# Altium schematic sheet DigitalLabBoard_Processor.SchDoc(DigitalLabBoard_Processor)
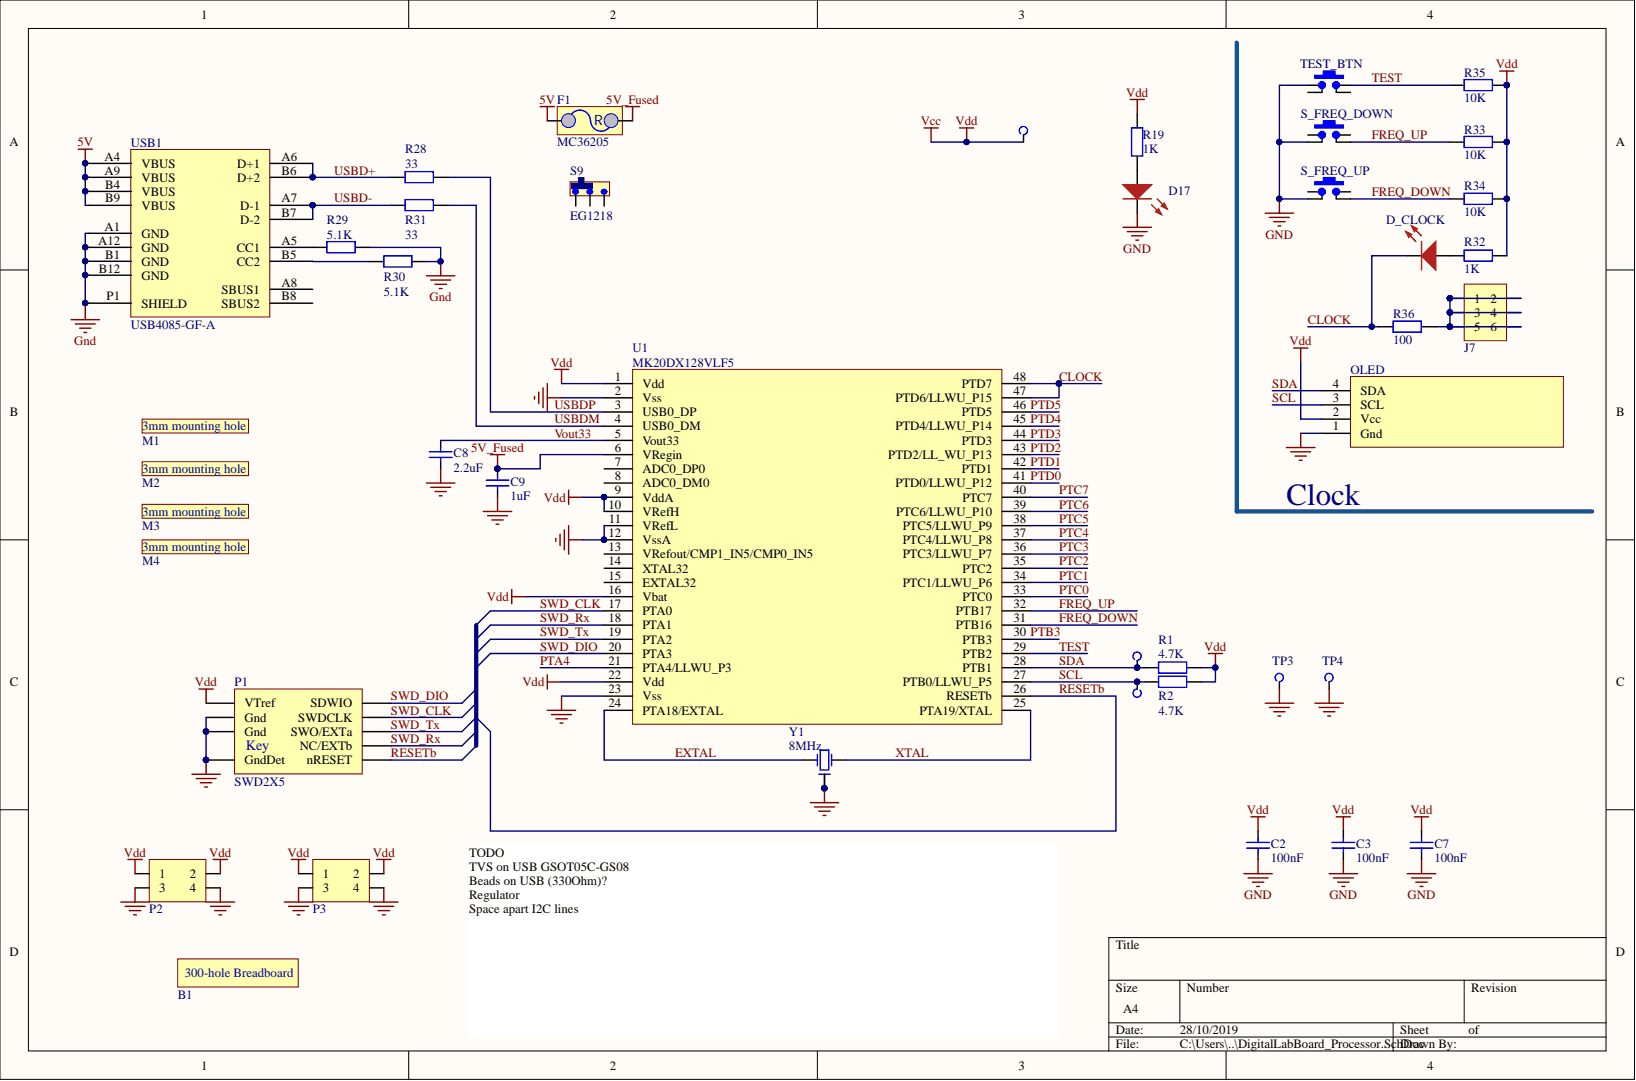
[diagram p0: DigitalLabBoard_Processor.SchDoc]

## Parts
B1  Breadboard - 300 Hole  [Breadboard - 300 holes]  (value not drawn)
C2  100nF  [CCNP1608-0603]
C3  100nF  [CCNP1608-0603]
C7  100nF  [CCNP1608-0603]
C8  2.2uF  [CCNP1608-0603]
C9  1uF  [CCNP1608-0603]
D17  LED Red  [LED1608-0603-RED]  (value not drawn)
D_CLOCK  LED Red  [LED1608-0603-RED]  (value not drawn)
F1  MC36205  [CR3225-1210]
J7  2x5 Header  [HDR2X3S]  (value not drawn)
M1  3mm mountng hole  [3MM_Hole]  (value not drawn)  (no BOM)
M2  3mm mountng hole  [3MM_Hole]  (value not drawn)  (no BOM)
M3  3mm mountng hole  [3MM_Hole]  (value not drawn)  (no BOM)
M4  3mm mountng hole  [3MM_Hole]  (value not drawn)  (no BOM)
OLED  OLED_Display  [OLED_Display]  (value not drawn)
P1  SWD2X5  [SWD-2x5]
P2  Header 2x2  [HDR_FEM2X2S]  (value not drawn)
P3  Header 2x2  [HDR_FEM2X2S]  (value not drawn)
R1  4.7K  [CR1608-0603]
R2  4.7K  [CR1608-0603]
R19  1K  [CR1608-0603]
R28  33  [CR1608-0603]
R29  5.1K  [CR1608-0603]
R30  5.1K  [CR1608-0603]
R31  33  [CR1608-0603]
R32  1K  [CR1608-0603]
R33  10K  [CR1608-0603]
R34  10K  [CR1608-0603]
R35  10K  [CR1608-0603]
R36  100  [CR1608-0603]
S9  EG1218  [Slide Switch -  EG1218]
S_FREQ_DOWN  SW_PB  [SW-SMD-4_5.1x5.1x2]  (value not drawn)
S_FREQ_UP  SW_PB  [SW-SMD-4_5.1x5.1x2]  (value not drawn)
TEST_BTN  SW_PB  [SW-SMD-4_5.1x5.1x2]  (value not drawn)
TP3  Gnd  [PCBPIN - 0.9mm]  (value not drawn)  (no BOM)
TP4  Gnd  [PCBPIN - 0.9mm]  (value not drawn)  (no BOM)
TP_SCL  SCL  [PCBPIN - 0.9mm]  (value not drawn)  (no BOM)
TP_SDA  SDA  [PCBPIN - 0.9mm]  (value not drawn)  (no BOM)
TP_Vdd  Vdd  [PCBPIN - 0.9mm]  (no BOM)
U1  MK20DX128VLF5  [TSQFP50P900X900-48M]
USB1  USB4085-GF-A  [USB4085-GF-A]
Y1  8MHz  [Crystal_SMT - EPSON-FA-238]

## Nets
5V: F1.1 USB1.A4(VBUS) USB1.A9(VBUS) USB1.B4(VBUS) USB1.B9(VBUS)
5V_Fused: C9.2 F1.2 U1.6(VRegin)
Gnd: C2.1 C3.1 C7.1 C8.1 C9.1 D17.K OLED.1(Gnd) P1.3(Gnd) P1.5(Gnd) P1.9(GndDet) P2.3 P2.4 P3.3 P3.4 R29.2 R30.2 S_FREQ_DOWN.2 S_FREQ_UP.2 TEST_BTN.2b TP3.1 TP4.1 U1.2(Vss) U1.11(VRefL) U1.12(VssA) U1.23(Vss) USB1.A1(GND) USB1.A12(GND) USB1.B1(GND) USB1.B12(GND) USB1.P1(SHIELD) Y1.2 Y1.4
Vcc: C2.2 C3.2 C7.2 OLED.2(Vcc) P1.1(VTref) P2.1 P2.2 P3.1 P3.2 R1.2 R2.2 R19.2 R32.2 R33.2 R34.2 R35.2 TP_Vdd.1 U1.1(Vdd) U1.9(VddA) U1.10(VRefH) U1.16(Vbat) U1.22(Vdd)
CLOCK: D_CLOCK.K R36.2 U1.47(PTD6/LLWU_P15) U1.48(PTD7)
EXTAL: U1.24(PTA18/EXTAL) Y1.3
FREQ_DOWN: R34.1 S_FREQ_UP.1 U1.31(PTB16)
FREQ_UP: R33.1 S_FREQ_DOWN.1 U1.32(PTB17)
PTA4: U1.21(PTA4/LLWU_P3)
PTB3: U1.30(PTB3)
PTC0: U1.33(PTC0)
PTC1: U1.34(PTC1/LLWU_P6)
PTC2: U1.35(PTC2)
PTC3: U1.36(PTC3/LLWU_P7)
PTC4: U1.37(PTC4/LLWU_P8)
PTC5: U1.38(PTC5/LLWU_P9)
PTC6: U1.39(PTC6/LLWU_P10)
PTC7: U1.40(PTC7)
PTD0: U1.41(PTD0/LLWU_P12)
PTD1: U1.42(PTD1)
PTD2: U1.43(PTD2/LL_WU_P13)
PTD3: U1.44(PTD3)
PTD4: U1.45(PTD4/LLWU_P14)
PTD5: U1.46(PTD5)
RESETb: P1.10(nRESET) U1.26(RESETb)
SCL: OLED.3(SCL) R2.1 TP_SCL.1 U1.27(PTB0/LLWU_P5)
SDA: OLED.4(SDA) R1.1 TP_SDA.1 U1.28(PTB1)
SWD_CLK: P1.4(SWDCLK) U1.17(PTA0)
SWD_DIO: P1.2(SDWIO) U1.20(PTA3)
SWD_Rx: P1.8(NC/EXTb) U1.18(PTA1)
SWD_Tx: P1.6(SWO/EXTa) U1.19(PTA2)
TEST: R35.1 TEST_BTN.1b U1.29(PTB2)
USBD+: R28.1 USB1.A6(D+1) USB1.B6(D+2)
USBD-: R31.1 USB1.A7(D-1) USB1.B7(D-2)
USBDM: R31.2 U1.4(USB0_DM)
USBDP: R28.2 U1.3(USB0_DP)
Vout33: C8.2 U1.5(Vout33)
XTAL: U1.25(PTA19/XTAL) Y1.1
NetD17_A: D17.A R19.1
NetD_CLOCK_A: D_CLOCK.A R32.1
NetJ7_1: J7.1 J7.2 J7.3 J7.4 J7.5 J7.6 R36.1
NetR29_1: R29.1 USB1.A5(CC1)
NetR30_1: R30.1 USB1.B5(CC2)
N00095:

Not connected: S9 1 2 3; S_FREQ_DOWN 1b 2b; S_FREQ_UP 1b 2b; TEST_BTN 1 2; U1 7(ADC0_DP0) 8(ADC0_DM0) 13(VRefout/CMP1_IN5/CMP0_IN5) 14(XTAL32) 15(EXTAL32); USB1 A8(SBUS1) B8(SBUS2)

## Library data of the parts
B1 = Breadboard - 300 holes: Library Name: MySchLib.schlib; Component Kind: Standard
C2 C3 C7 = Cap_CCNP_0603: Capacitor non-polar SMT 0603; Manufacturer: Generic Components; Class: Passive; Value: 100nF; SubClass: Capacitor; Simulation: CAP; Signal Integrity: Cap; Published: 08/06/2000; Library Name: MyLibrary.IntLib; Component Kind: Standard; Publisher: Altium Hobart Technology Centre
C8 C9 = Cap: Capacitor; Manufacturer: Generic Components; Class: Passive; SubClass: Capacitor; Simulation: CAP; Signal Integrity: Cap; Published: 08/06/2000; Library Name: MyLibrary.IntLib; Component Kind: Standard; Publisher: Altium Hobart Technology Centre
  C8: Value: 2.2uF
  C9: Value: 1uF
D17 D_CLOCK = LED-0603-Red: LED 0603 Red; Manufacturer: Generic Components; Class I: Optoelectronic; Revision: July-2002: Re-released for DXP Platform.; Published: 8-Jun-2000; Farnell PN: 1581239; Component Kind: Standard; Class II: LED; Publisher: Altium Limited
  D17: Library Name: MyLibrary.IntLib
  D_CLOCK: Library Name: MySchLib.schlib
F1 = MC36205: PPTC Resettable Fuse; Library Name: MyLibrary.IntLib; Farnell PN: 1861178; Component Kind: Standard
J7 = Header 2x3a: Header 2x3; Library Name: MyLibrary.IntLib; Component Kind: Standard
M1-M4 = Mounting_Hole_3mm: Library Name: MyLibrary.IntLib
P1 = SWD2X5: SWD Connector 2x5-Pin; Signal Integrity: Connector; Library Name: MySchLib.schlib; LatestRevisionNote: Re-released for DXP Platform.; LatestRevisionDate: 17-Jul-2002; Component Kind: Standard; Publisher: Altium Limited
P2 P3 = Header Female 2x2S: Header, Female, 2x2-Pin; Library Name: MyLibrary.IntLib; Component Kind: Standard
R1 R2 R19 R28 R31 = Res: Resistor; Manufacturer: Generic Components; Class: Passive; SubClass: Resistor; Simulation: RESISTOR; Signal Integrity: Res; Published: 08/06/2000; Library Name: MyLibrary.IntLib; Component Kind: Standard; Publisher: Altium Hobart Technology Centre
  R1 R2: Value: 4.7K
  R19: Value: 1K
  R28 R31: Value: 33
R29 R30 R32-R36 = Res_CR0603: Resistor SMT 0603; Manufacturer: Generic Components; Class: Passive; SubClass: Resistor; Simulation: RESISTOR; Signal Integrity: Res; Published: 08/06/2000; Library Name: MyLibrary.IntLib; Component Kind: Standard; Publisher: Altium Hobart Technology Centre
  R29 R30: Value: 5.1K
  R32: Value: 1K
  R33-R35: Value: 10K
  R36: Value: 100
S9 = SW-SLIDE_EG1218: Small PCB slide switch   E-Switch EG1218; Manufacturer: Generic Components; Revision: July-2002: Re-released for DXP Platform.; Published: 24-Mar-1999; Library Name: MyLibrary.IntLib; Component Kind: Standard; Publisher: Altium Limited
S_FREQ_DOWN S_FREQ_UP TEST_BTN = SW_PB-ALPS-SKQGAFE010: Tactile Switch, Top Actuated, Surface Mount, Round Button; Manufacturer: Multicomp; Revision: 1.0; Library Name: MySchLib.schlib; Farnell PN: 9471880; Component Kind: Standard
TP3 TP4 TP_SCL TP_SDA TP_Vdd = TP: Test Point; Library Name: MyLibrary.IntLib
U1 = MK20DX128VLF5: Arm Cortex M4; Library Name: MySchLib.schlib; Component Kind: Standard
USB1 = (no symbol name): USB - C (Type - C) USB 2.0 Receptacle Connector 16 Position Through Hole, Right Angle; Angle: 90; Part Number: USB4085-GF-A; Library Name: MySchLib.schlib; Digikey Part Number: 2073-USB4085-GF-ACT-ND; Digikey Link: https://www.digikey.ee/product-detail/en/gct/USB4085-GF-A/2073-USB4085-GF-ACT-ND/9859733; Component Kind: Standard
Y1 = XTAL_SMT2_FA-238: Crystal (EPSON  Q22FA23800065 FA-238 24 MHZ 12.0PF); Manufacturer: Generic Components; SubClass: Crystal Oscillator; Class: Oscillator; Published: 08/06/2000; Library Name: MyLibrary.IntLib; Farnell PN: 1712815; Component Kind: Standard; Publisher: Altium Hobart Technology Centre
OLED = OLED_Display: Library Name: MySchLib.schlib; Component Kind: Standard
